# Altium schematic sheet Ethernet.SchDoc
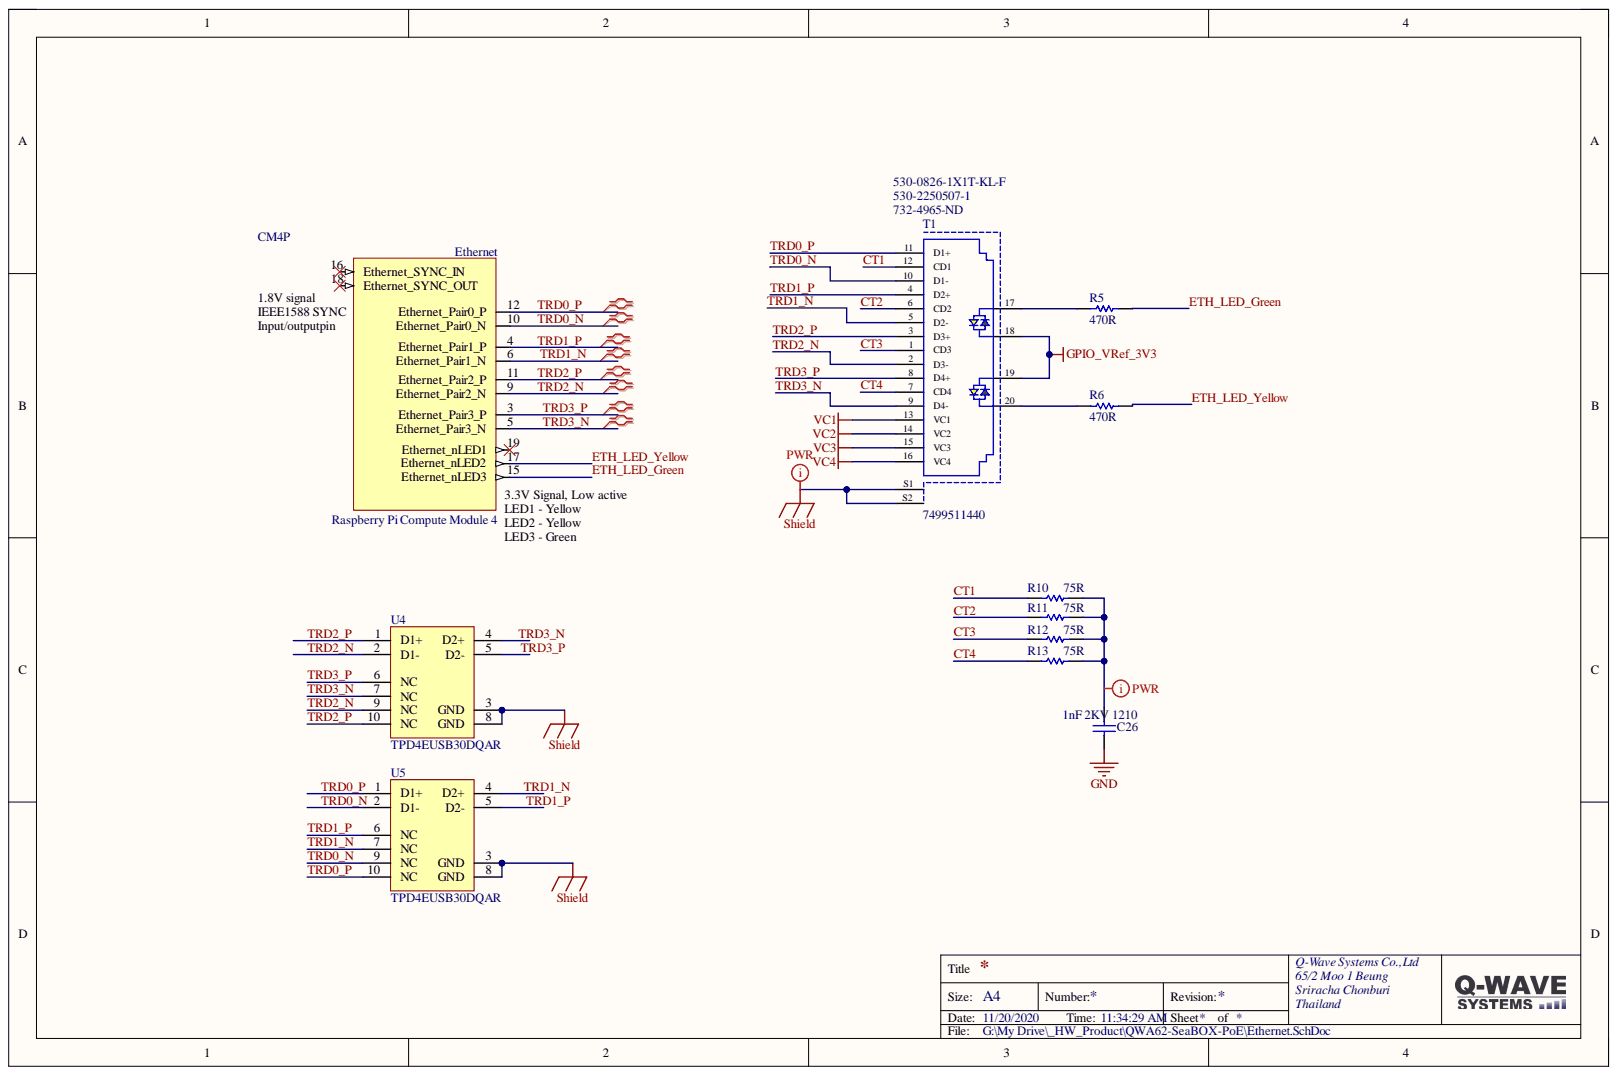
[diagram p0: Ethernet.SchDoc]

## Parts
C26  1nF 2KV 1210  [C1210]
CM4  Raspberry Pi Compute Module 4  [CM4-DF40HC(3.0)-100DS-0.4v_3mm_1.5mm]  (part P)
R5  470R  [RES_0603]
R6  470R  [RES_0603]
R10  75R  [RES_0603]
R11  75R  [RES_0603]
R12  75R  [RES_0603]
R13  75R  [RES_0603]
T1  7499511440  Supplier Part Number 1: 732-4965-ND  [7499511440]
U4  TPD4EUSB30DQAR  [DQA0010A_V]
U5  TPD4EUSB30DQAR  [DQA0010A_V]

## Nets
GND: C26.1
GPIO_VRef_3V3: T1.18 T1.19
Shield: T1.S1 T1.S2 U4.3(GND) U4.8(GND) U5.3(GND) U5.8(GND)
VC1: T1.13(VC1)
VC2: T1.14(VC2)
VC3: T1.15(VC3)
VC4: T1.16(VC4)
CT1: R10.2 T1.12(CD1)
CT2: R11.2 T1.6(CD2)
CT3: R12.2 T1.1(CD3)
CT4: R13.2 T1.7(CD4)
ETH_LED_Green: CM4.15(Ethernet_nLED3) R5.1
ETH_LED_Yellow: CM4.17(Ethernet_nLED2) R6.1
TRD0_N: CM4.10(Ethernet_Pair0_N) T1.10(D1-) U5.2(D1-) U5.9(NC)
TRD0_P: CM4.12(Ethernet_Pair0_P) T1.11(D1+) U5.1(D1+) U5.10(NC)
TRD1_N: CM4.6(Ethernet_Pair1_N) T1.5(D2-) U5.4(D2+) U5.7(NC)
TRD1_P: CM4.4(Ethernet_Pair1_P) T1.4(D2+) U5.5(D2-) U5.6(NC)
TRD2_N: CM4.9(Ethernet_Pair2_N) T1.2(D3-) U4.2(D1-) U4.9(NC)
TRD2_P: CM4.11(Ethernet_Pair2_P) T1.3(D3+) U4.1(D1+) U4.10(NC)
TRD3_N: CM4.5(Ethernet_Pair3_N) T1.9(D4-) U4.4(D2+) U4.7(NC)
TRD3_P: CM4.3(Ethernet_Pair3_P) T1.8(D4+) U4.5(D2-) U4.6(NC)
NetC26_2: C26.2 R10.1 R11.1 R12.1 R13.1
NetR5_2: R5.2 T1.17
NetR6_2: R6.2 T1.20

Not connected: CM4 16(Ethernet_SYNC_IN) 18(Ethernet_SYNC_OUT) 19(Ethernet_nLED1)

## Library data of the parts
C26 = Cap Semi: MFG: KEMET; Component Kind: Standard; Description: 1nF 2KV 1210; Library Name: Miscellaneous Devices.IntLib; Signal Integrity: Cap Semi; Simulation: CAP
CM4 = CM4: Component Kind: Standard; Description: Raspberry Pi Compute Module 4; Library Name: CM4.SchLib
R5 R6 R10-R13 = Res Semi: Resistor 0603; Component Kind: Standard; Library Name: Miscellaneous Devices.IntLib; Signal Integrity: Res Semi; Simulation: RES
T1 = 7499511440: WE-RJ45 LAN Transformer, THT, 10/100/1000 Base-T, Port 1x1, Tab Up; Manufacturer: Wurth Elektronik; HPLE: No; LED: green/yellow-green/yellow; PoE: PoE+ (up to 600 mA); Shield Tabs: Yes; Component Kind: Standard; ComponentLink1Description: Manufacturer; ComponentLink1URL: http://www.we-online.de; ComponentLink2Description: Datasheet; ComponentLink2URL: https://www.we-online.com/catalog/datasheet/7499511440.pdf; Data Rate: 10/100/1000 Base-T; Library Name: 7499511440_Altium_WE-RJ45LAN_rev20c.IntLib; Manufacturer Part Number: 7499511440; Mounting Technology: THT; Operating Temperature: -40 to +85degC; Packaging: Tray; Port Number: 1x1; Published Date: April 2020; Supplier 1: Digi-Key; Supplier 2: Mouser; Supplier 3: Mouser; Supplier Part Number 2: 530-2250507-1; Supplier Part Number 3: 530-0826-1X1T-KL-F; Tab: Up; USB: No
U4 U5 = CMP-0326-00027-3: ESD Solution for Super-Speed (6 GBPS) USB 3.0 Interface, 4 Channels, -40 to +85 degC, 10-pin SON (DQA), Green (RoHS & no Sb/Br); Manufacturer: Texas Instruments; 1394 / Firewire: TRUE; Breakdown Voltage(Min)(V): 8; Differential Capacitance(pF): 0.05; IO Capacitance(Typ)(pF): 0.8; IO Leakage Current(nA): 10; Component Kind: Standard; ComponentLink1Description: Manufacturer URL; ComponentLink1URL: http://www.ti.com/; ComponentLink2Description: Datasheet; ComponentLink2URL: http://www.ti.com/general/docs/lit/getliterature.tsp?genericPartNumber=TPD4EUSB30&fileType=pdf; ComponentLink3Description: URL Link1; ComponentLink3URL: SamplesURL:http://www.ti.com/product/tpd4eusb30#samples; DatasheetVersion: SLVSAC2C; Eco-Plan: Green (RoHS & no Sb/Br); HDMI: TRUE; IEC 61000-4-2 Air-Gap(+/- kV): 8; IEC 61000-4-2 Contact(+/- kV): 8; Library Name: Altium Content Vault; Mounting Technology: Surface Mount; PackageDescription: 10-Pin Plastic Small Outline No-Lead, 1 x 2.5 x 0.55 mm Body, 0.5 mm Pitch; PackageReference: DQA0010A; PackageVersion: revB, Sep-2009; PartNumber: TPD4EUSB30DQAR; Serial ATA / eSATA: TRUE; SubFamily: ESD Solution; USB Full Speed: TRUE; USB High Speed: TRUE; USB Super Speed: TRUE
